# Altium schematic sheet CPU.SchDoc
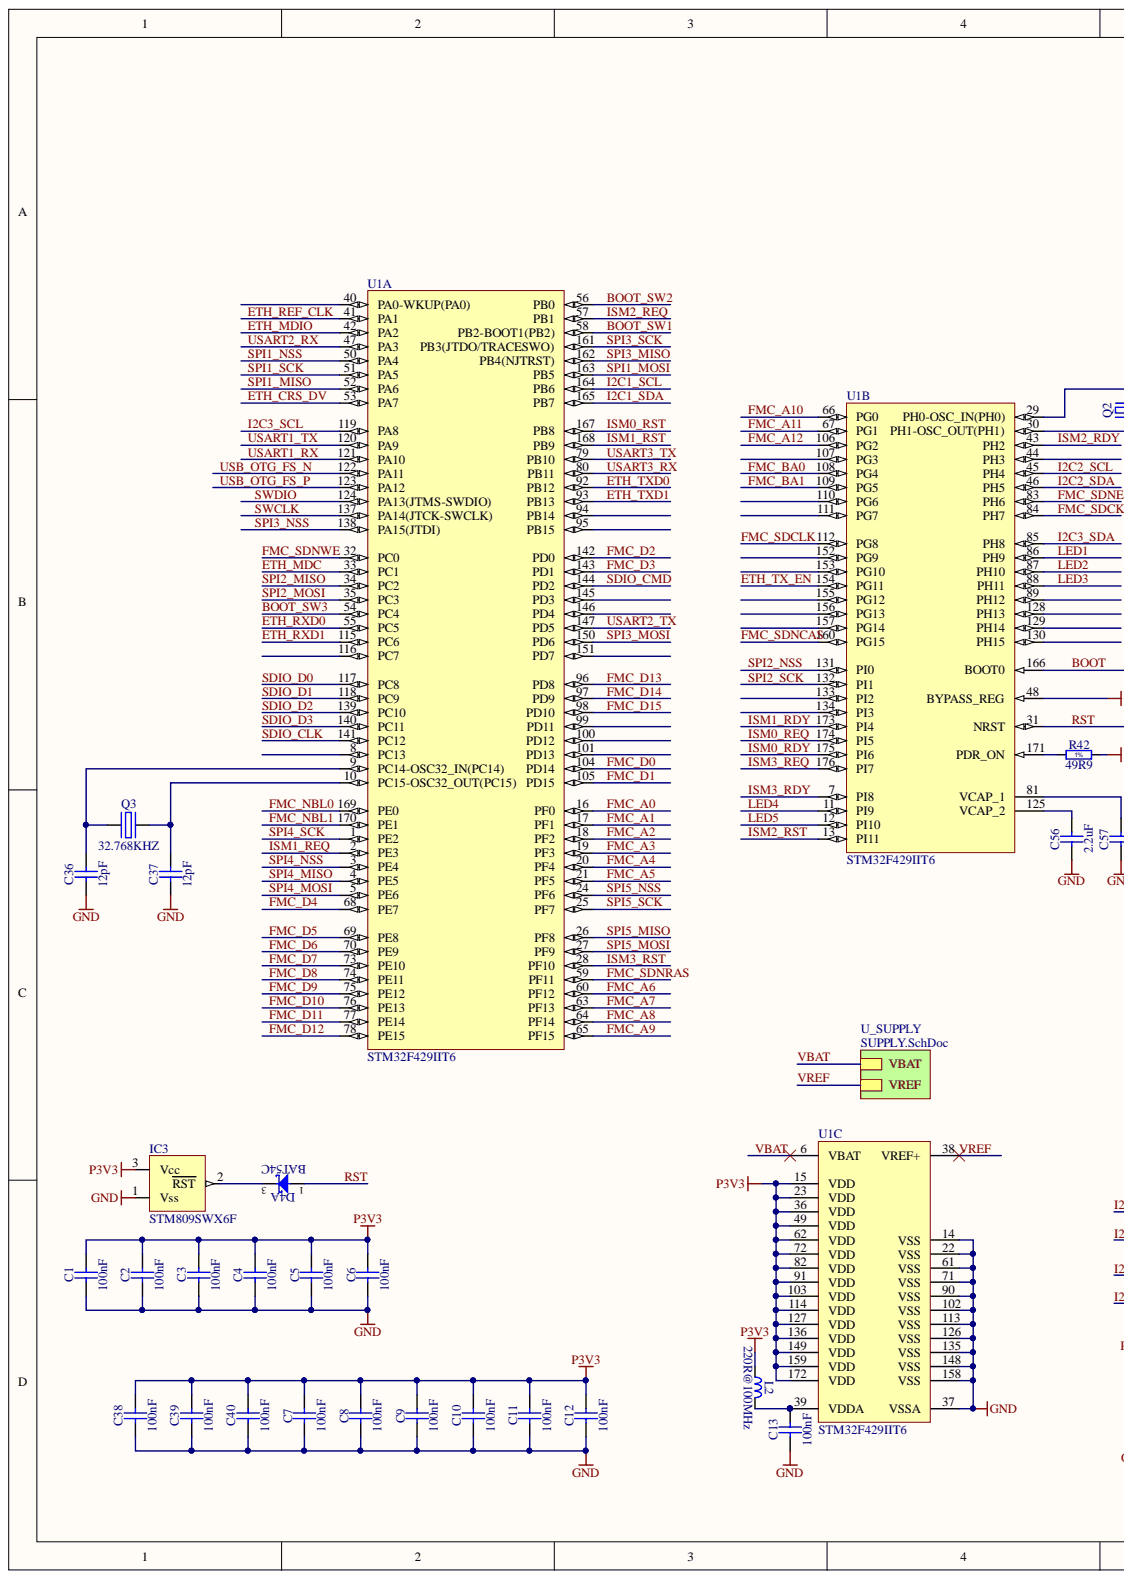
[diagram p0: CPU.SchDoc, left]
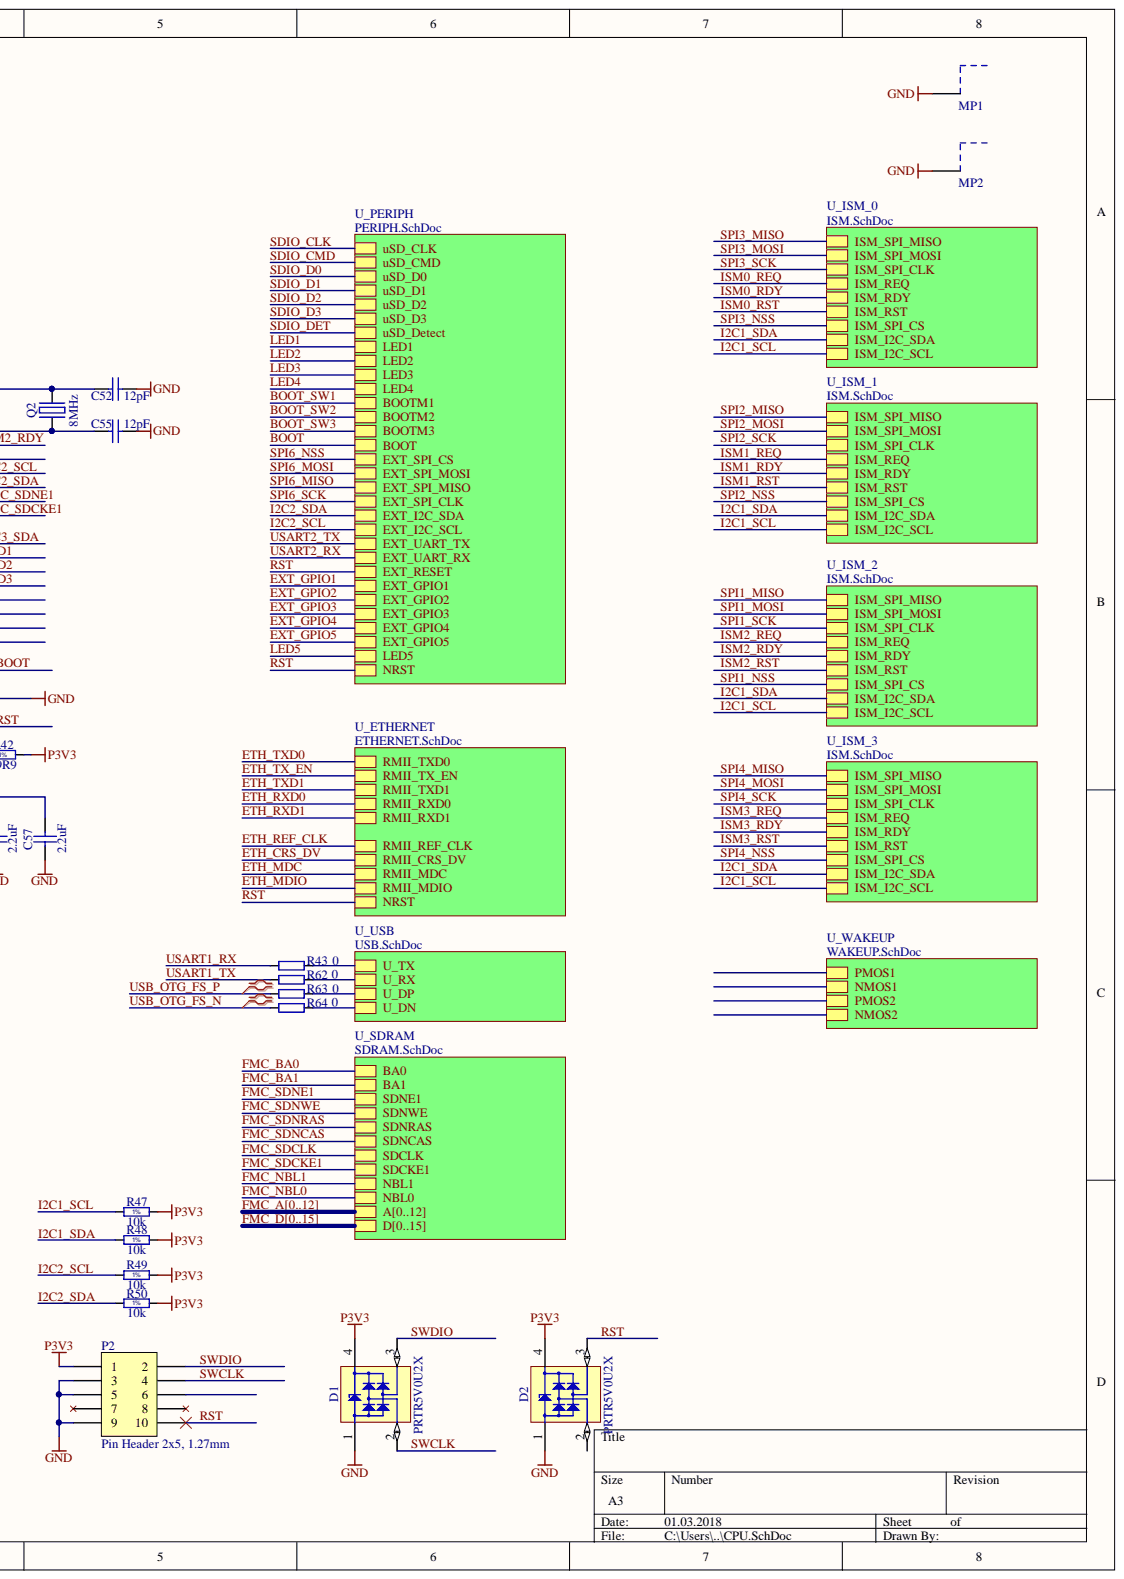
[diagram p1: CPU.SchDoc, right]

## Parts
C1  100nF  [CAPC1005X55N]
C2  100nF  [CAPC1005X55N]
C3  100nF  [CAPC1005X55N]
C4  100nF  [CAPC1005X55N]
C5  100nF  [CAPC1005X55N]
C6  100nF  [CAPC1005X55N]
C7  100nF  [CAPC1005X55N]
C8  100nF  [CAPC1005X55N]
C9  100nF  [CAPC1005X55N]
C10  100nF  [CAPC1005X55N]
C11  100nF  [CAPC1005X55N]
C12  100nF  [CAPC1005X55N]
C13  100nF  [CAPC1005X55N]
C36  12pF  [CAPC1005X55N]
C37  12pF  [CAPC1005X55N]
C38  100nF  [CAPC1005X55N]
C39  100nF  [CAPC1005X55N]
C40  100nF  [CAPC1005X55N]
C52  12pF  [CAPC1005X55N]
C55  12pF  [CAPC1005X55N]
C56  2.2uF  [CAPC1608X87N]
C57  2.2uF  [CAPC1608X87N]
D1  PRTR5V0U2X  [SOT190P230X110-4N]
D2  PRTR5V0U2X  [SOT190P230X110-4N]
D4  BAT54C  [SOT95P240X130-3N]  (part A)
IC3  STM809SWX6F  [SOT95P229X119-3N]
L2  220R@100MHz  [INDC2012X105N]
MP1  36103205S  [36103205S]  (value not drawn)
MP2  36103205S  [36103205S]  (value not drawn)
P2  Pin Header 2x5, 1.27mm  [HDR_2x5-SMD-1.27mm-K]
Q2  8MHz  [XTAL_TXC_7A]
Q3  32.768KHZ  [XTAL_ABRACON_ABS09]
R42  49R9  [RESC1005X40N]
R43  0  [RESC1005X40N]
R47  10k  [RESC1005X40N]
R48  10k  [RESC1005X40N]
R49  10k  [RESC1005X40N]
R50  10k  [RESC1005X40N]
R62  0  [RESC1005X40N]
R63  0  [RESC1005X40N]
R64  0  [RESC1005X40N]
U1  STM32F429IIT6  [STM-LQFP176_N]  (parts A,B,C)

## Nets
GND: C1.1 C2.1 C3.1 C4.1 C5.1 C6.1 C7.1 C8.1 C9.1 C10.1 C11.1 C12.1 C13.1 C36.1 C37.1 C38.1 C39.1 C40.1 C52.1 C55.1 C56.1 C57.1 D1.1 D2.1 IC3.1 MP1.1 MP2.1 P2.3 P2.5 P2.9 U1.14(VSS) U1.22(VSS) U1.37(VSSA) U1.48(BYPASS_REG) U1.61(VSS) U1.71(VSS) U1.90(VSS) U1.102(VSS) U1.113(VSS) U1.126(VSS) U1.135(VSS) U1.148(VSS) U1.158(VSS)
P3V3: C1.2 C2.2 C3.2 C4.2 C5.2 C6.2 C7.2 C8.2 C9.2 C10.2 C11.2 C12.2 C38.2 C39.2 C40.2 D1.4 D2.4 IC3.3 L2.1 P2.1 R42.2 R47.2 R48.2 R49.2 R50.2 U1.15(VDD) U1.23(VDD) U1.36(VDD) U1.49(VDD) U1.62(VDD) U1.72(VDD) U1.82(VDD) U1.91(VDD) U1.103(VDD) U1.114(VDD) U1.127(VDD) U1.136(VDD) U1.149(VDD) U1.159(VDD) U1.172(VDD)
BOOT: U1.166(BOOT0)
BOOT_SW1: U1.58(PB2-BOOT1(PB2))
BOOT_SW2: U1.56(PB0)
BOOT_SW3: U1.54(PC4)
ETH_CRS_DV: U1.53(PA7)
ETH_MDC: U1.33(PC1)
ETH_MDIO: U1.42(PA2)
ETH_REF_CLK: U1.41(PA1)
ETH_RXD0: U1.55(PC5)
ETH_RXD1: U1.115(PC6)
ETH_TX_EN: U1.154(PG11)
ETH_TXD0: U1.92(PB12)
ETH_TXD1: U1.93(PB13)
FMC_A0: U1.16(PF0)  [also labelled FMC_A]
FMC_A1: U1.17(PF1)  [also labelled FMC_A]
FMC_A2: U1.18(PF2)  [also labelled FMC_A]
FMC_A3: U1.19(PF3)  [also labelled FMC_A]
FMC_A4: U1.20(PF4)  [also labelled FMC_A]
FMC_A5: U1.21(PF5)  [also labelled FMC_A]
FMC_A6: U1.60(PF12)  [also labelled FMC_A]
FMC_A7: U1.63(PF13)  [also labelled FMC_A]
FMC_A8: U1.64(PF14)  [also labelled FMC_A]
FMC_A9: U1.65(PF15)  [also labelled FMC_A]
FMC_A10: U1.66(PG0)  [also labelled FMC_A]
FMC_A11: U1.67(PG1)  [also labelled FMC_A]
FMC_A12: U1.106(PG2)  [also labelled FMC_A]
FMC_BA0: U1.108(PG4)
FMC_BA1: U1.109(PG5)
FMC_D0: U1.104(PD14)  [also labelled FMC_D]
FMC_D1: U1.105(PD15)  [also labelled FMC_D]
FMC_D2: U1.142(PD0)  [also labelled FMC_D]
FMC_D3: U1.143(PD1)  [also labelled FMC_D]
FMC_D4: U1.68(PE7)  [also labelled FMC_D]
FMC_D5: U1.69(PE8)  [also labelled FMC_D]
FMC_D6: U1.70(PE9)  [also labelled FMC_D]
FMC_D7: U1.73(PE10)  [also labelled FMC_D]
FMC_D8: U1.74(PE11)  [also labelled FMC_D]
FMC_D9: U1.75(PE12)  [also labelled FMC_D]
FMC_D10: U1.76(PE13)  [also labelled FMC_D]
FMC_D11: U1.77(PE14)  [also labelled FMC_D]
FMC_D12: U1.78(PE15)  [also labelled FMC_D]
FMC_D13: U1.96(PD8)  [also labelled FMC_D]
FMC_D14: U1.97(PD9)  [also labelled FMC_D]
FMC_D15: U1.98(PD10)  [also labelled FMC_D]
FMC_NBL0: U1.169(PE0)
FMC_NBL1: U1.170(PE1)
FMC_SDCKE1: U1.84(PH7)
FMC_SDCLK: U1.112(PG8)
FMC_SDNCAS: U1.160(PG15)
FMC_SDNE1: U1.83(PH6)
FMC_SDNRAS: U1.59(PF11)
FMC_SDNWE: U1.32(PC0)
I2C1_SCL: R47.1 U1.164(PB6)
I2C1_SDA: R48.1 U1.165(PB7)
I2C2_SCL: R49.1 U1.45(PH4)
I2C2_SDA: R50.1 U1.46(PH5)
I2C3_SCL: U1.119(PA8)
I2C3_SDA: U1.85(PH8)
ISM0_RDY: U1.175(PI6)
ISM0_REQ: U1.174(PI5)
ISM0_RST: U1.167(PB8)
ISM1_RDY: U1.173(PI4)
ISM1_REQ: U1.2(PE3)
ISM1_RST: U1.168(PB9)
ISM2_RDY: U1.43(PH2)
ISM2_REQ: U1.57(PB1)
ISM2_RST: U1.13(PI11)
ISM3_RDY: U1.7(PI8)
ISM3_REQ: U1.176(PI7)
ISM3_RST: U1.28(PF10)
LED1: U1.86(PH9)
LED2: U1.87(PH10)
LED3: U1.88(PH11)
LED4: U1.11(PI9)
LED5: U1.12(PI10)
RST: D2.3 D4.1 P2.10 U1.31(NRST)
SDIO_CLK: U1.141(PC12)
SDIO_CMD: U1.144(PD2)
SDIO_D0: U1.117(PC8)
SDIO_D1: U1.118(PC9)
SDIO_D2: U1.139(PC10)
SDIO_D3: U1.140(PC11)
SPI1_MISO: U1.52(PA6)
SPI1_MOSI: U1.163(PB5)
SPI1_NSS: U1.50(PA4)
SPI1_SCK: U1.51(PA5)
SPI2_MISO: U1.34(PC2)
SPI2_MOSI: U1.35(PC3)
SPI2_NSS: U1.131(PI0)
SPI2_SCK: U1.132(PI1)
SPI3_MISO: U1.162(PB4(NJTRST))
SPI3_MOSI: U1.150(PD6)
SPI3_NSS: U1.138(PA15(JTDI))
SPI3_SCK: U1.161(PB3(JTDO/TRACESWO))
SPI4_MISO: U1.4(PE5)
SPI4_MOSI: U1.5(PE6)
SPI4_NSS: U1.3(PE4)
SPI4_SCK: U1.1(PE2)
SPI5_MISO: U1.26(PF8)
SPI5_MOSI: U1.27(PF9)
SPI5_NSS: U1.24(PF6)
SPI5_SCK: U1.25(PF7)
SWCLK: D1.2 P2.4 U1.137(PA14(JTCK-SWCLK))
SWDIO: D1.3 P2.2 U1.124(PA13(JTMS-SWDIO))
USART1_RX: R43.1 U1.121(PA10)
USART1_TX: R62.2 U1.120(PA9)
USART2_RX: U1.47(PA3)
USART2_TX: U1.147(PD5)
USART3_RX: U1.80(PB11)
USART3_TX: U1.79(PB10)
USB_OTG_FS_N: R64.2 U1.122(PA11)
USB_OTG_FS_P: R63.1 U1.123(PA12)
VBAT: U1.6(VBAT)
VREF: U1.38(VREF+)
NetC13_2: C13.2 L2.2 U1.39(VDDA)
NetC36_2: C36.2 Q3.1 U1.9(PC14-OSC32_IN(PC14))
NetC37_2: C37.2 Q3.2 U1.10(PC15-OSC32_OUT(PC15))
NetC52_2: C52.2 Q2.2 U1.29(PH0-OSC_IN(PH0))
NetC55_2: C55.2 Q2.1 U1.30(PH1-OSC_OUT(PH1))
NetC56_2: C56.2 U1.125(VCAP_2)
NetC57_2: C57.2 U1.81(VCAP_1)
NetD2_2: D2.2
NetD4_3: D4.3 IC3.2
NetP2_6: P2.6
NetP2_7: P2.7
NetP2_8: P2.8
NetR42_1: R42.1 U1.171(PDR_ON)
NetR43_2: R43.2
NetR62_1: R62.1
NetR63_2: R63.2
NetR64_1: R64.1
NetU1_8: U1.8(PC13)
NetU1_40: U1.40(PA0-WKUP(PA0))
NetU1_44: U1.44(PH3)
NetU1_89: U1.89(PH12)
NetU1_94: U1.94(PB14)
NetU1_95: U1.95(PB15)
NetU1_99: U1.99(PD11)
NetU1_100: U1.100(PD12)
NetU1_101: U1.101(PD13)
NetU1_107: U1.107(PG3)
NetU1_110: U1.110(PG6)
NetU1_111: U1.111(PG7)
NetU1_116: U1.116(PC7)
NetU1_128: U1.128(PH13)
NetU1_129: U1.129(PH14)
NetU1_130: U1.130(PH15)
NetU1_133: U1.133(PI2)
NetU1_134: U1.134(PI3)
NetU1_145: U1.145(PD3)
NetU1_146: U1.146(PD4)
NetU1_151: U1.151(PD7)
NetU1_152: U1.152(PG9)
NetU1_153: U1.153(PG10)
NetU1_155: U1.155(PG12)
NetU1_156: U1.156(PG13)
NetU1_157: U1.157(PG14)
EXT_GPIO1:
EXT_GPIO2:
EXT_GPIO3:
EXT_GPIO4:
EXT_GPIO5:
NMOS1:
NMOS2:
PMOS1:
PMOS2:
SDIO_DET:
SPI6_MISO:
SPI6_MOSI:
SPI6_NSS:
SPI6_SCK:

## Library data of the parts
C1-C13 C38-C40 = CC0402_100NF_16V_10%_X5R: Non-polarised capacitor; Manufacturer: GENERIC; Manufacturer1 Part Number: GRM155R61C104KA88D; Part Description: SMD Multilayer Chip Ceramic Capacitor; Database Table Name: Capacitors SMD; TC: X5R; Tolerance: ±10%; Voltage: 16V; Case: 0402; Component Kind: Standard; Component Type: Standard; CreateDate: 2013-12-10; HelpURL: \\cern.ch\dfs\Applications\Altium\Datasheets\CC0402_X5R_MURATA_GRM.pdf; LatestRevisionDate: 2013-12-10; Library Name: Capacitors.DbLib; Manufacturer1 ComponentHeight: 0.55mm; Manufacturer1 Example: MURATA; Manufacturer Part Number: CC0402_100NF_16V_10%_X5R; Mounted: Yes; Part Number: CC0402_100NF_16V_10%_X5R; Pin Count: 2; Sense: No; SMD: Yes; Socket: No; Status: None; Value: 100nF; Author: CERN DEM JLC
C36 C37 C52 C55 = CC0402_12PF_50V_5%_C0G: Non-polarised capacitor; Manufacturer: GENERIC; Manufacturer1 Part Number: C0402C120J5GACTU; Part Description: SMD Multilayer Chip Ceramic Capacitor; Database Table Name: Capacitors SMD; TC: C0G/NP0; Tolerance: ±5%; Voltage: 50V; Case: 0402; Component Kind: Standard; Component Type: Standard; CreateDate: 2016-10-04; HelpURL: \\cern.ch\dfs\Applications\Altium\Datasheets\CC0805_C0G_KEMET_(Commercial Grade).pdf; LatestRevisionDate: 2016-10-04; Library Name: Capacitors.DbLib; Manufacturer1 ComponentHeight: 0.55mm; Manufacturer1 Example: KEMET; Manufacturer Part Number: CC0402_12PF_50V_5%_C0G; Mounted: Yes; Part Number: CC0402_12PF_50V_5%_C0G; Pin Count: 2; Sense: No; SMD: Yes; Socket: No; Status: Preferred; Value: 12pF; Author: CERN DEM JLC
C56 C57 = CC0603_2.2UF_16V_10%_X5R: Non-polarised capacitor; Manufacturer: GENERIC; Manufacturer1 Part Number: CC0603KRX5R7BB225; Part Description: SMD Multilayer Chip Ceramic Capacitor; Database Table Name: Capacitors SMD; TC: X5R; Tolerance: ±10%; Voltage: 16V; Case: 0603; Component Kind: Standard; Component Type: Standard; CreateDate: 2011-04-28; HelpURL: \\cern.ch\dfs\Applications\Altium\Datasheets\CC0805_X5R_PHYCOMP_HC.pdf; LatestRevisionDate: 2011-04-28; Library Name: Capacitors.DbLib; Manufacturer1 ComponentHeight: 0.9mm; Manufacturer1 Example: YAGEO; Manufacturer Part Number: CC0603_2.2UF_16V_10%_X5R; Mounted: Yes; Part Number: CC0603_2.2UF_16V_10%_X5R; Pin Count: 2; Sense: No; SMD: Yes; Socket: No; Status: Preferred; Value: 2.2uF; Author: CERN DEM JLC
D1 D2 = PRTR5V0U2X: Ultra Low Capacitance Double Rail-to-Rail ESD Protection Diode; Manufacturer: NXP SEMICONDUCTORS; Part Description: Ultra Low Capacitance (1pF) Double Rail-to-Rail ESD Protection Diode (8kV); Bonding: No; Database Table Name: Diodes; PressFit: No; Voltage: 5.5V; Case: SOT143B; ComponentHeight: 1.1mm; Component Kind: Standard; Component Type: Standard; CreateDate: 22.03.2011; Device: PRTR5V0U2X; HelpURL: \\cern.ch\dfs\Applications\Altium\Datasheets\PRTR5V0U2X.pdf; LatestRevisionDate: 22.03.2011; Library Name: ICs And Semiconductors.DbLib; Manufacturer Part Number: PRTR5V0U2X; Mounted: Yes; PackageDescription: SOT143B, SOT, 1.90mm Pitch; 4 Pin, 1.30mm W X 2.90mm L X 1.10mm H body, IPC Medium Density; Part Number: PRTR5V0U2X; Pin Count: 4; Sense: No; SMD: Yes; Socket: No; Author: CERN DEM JLC
D4 = BAT54C: Dual Common Cathode Schottky Diodes; Manufacturer: FAIRCHILD SEMICONDUCTOR; Part Description: Dual Common Cathode Small Signal Schottky Diode; Bonding: No; Power: 200mA; PressFit: No; Voltage: 30V; Case: SOT23-3; ComponentHeight: 1.30mm; Component Kind: Standard; Component Type: Standard; CreateDate: 2009-09-17; Database Table Name: Diodes; Device: BAT54C; HelpURL: \\cern.ch\dfs\Applications\Altium\Datasheets\BAT54C.pdf; LatestRevisionDate: 2010-05-27; Library Name: ICs And Semiconductors.DbLib; Manufacturer Part Number: BAT54C; Mounted: Yes; PackageDescription: SOT23 3-Leads, Pitch 0.95mm - Body 1.3x2.9mm, IPC Medium Density; Part Number: BAT54C; Pin Count: 3; Sense: No; SMD: Yes; Socket: No; Author: CERN DEM JLC
IC3 = VMON_STM809: 2.93V  Reset circuit; Component Kind: Standard; Library Name: LIBRARY_SCH.SCHLIB
L2 = IND0805_MURATA_BLM21PG221SN1D: Inductor; Manufacturer: MURATA; Part Description: SMD EMIFIL Suppression Chip Ferrite Bead; Database Table Name: Inductors SMD; Power: 2A; Resistance: 0.05R; Tolerance: ±25%; Case: 0805; ComponentHeight: 1.05mm; Component Kind: Standard; Component Type: Standard; CreateDate: 2010-01-25; HelpURL: \\cern.ch\dfs\Applications\Altium\Datasheets\IND0402_MURATA_BLM21P.pdf; LatestRevisionDate: 2010-01-25; Library Name: Inductors & Transformers.DbLib; Manufacturer Part Number: BLM21PG221SN1D; Mounted: Yes; Part Number: IND0805_MURATA_BLM21PG221SN1D; Pin Count: 2; Sense: No; SMD: Yes; Socket: No; Status: None; Value: 220R@100MHz; Author: CERN DEM JLC
MP1 MP2 = 36103205S: WE-SHC Shielding Cabinet, SMD Frame, 20 x 20 x 3 mm; Component Kind: Standard; ComponentLink1Description: Manufacturer; ComponentLink1URL: http://www.we-online.de; ComponentLink2Description: Datasheet; ComponentLink2URL: http://katalog.we-online.de/pbs/datasheet/36103205S.pdf; Library Name: ALTIUM-WE-SHC-rev17b.IntLib; Part Number: 36103205S; Published Date: April 2017; Size: 20 x 20 x 3 mm; Type: SMD Frame; Publisher: Wurth Elektronik
P2 = Pin Header 2x5 SMD, 1.27mm, KS: Pin Header 2x5, 1.27mm (50mil), SMD, Keying Shroud; 2nd source part number: FTSH-105-01-F-DV-K; Manufacturer: WCON; Manufacturer Part Number: 3132-10MS0BK00R1; Artikkelnr Noca: 55010009; Artikkelnr Simpro: N.A.; Database Table Name: Connectors$; 2nd source Supplier: Samtec; Component Kind: Standard; Datasheet: \\hizz\pro\app9717\Datasheet\connectores\W-CON\3132-XXMXXBK00XX.pdf; Footprint Doc: HDR_2x5-SMD-1.27mm; LatestRevisionDate: 2016-04-21; Library Name: Nordic Passive DB.DbLib; Part Number: Pin Header 2x5 SMD, 1.27mm, KS; Pin Count: 10; Published: 2016-01-22; Supplier: Cabcon; Supplier part number: 3132-10MS0BK00R1; Value: Pin Header 2x5, 1.27mm; Publisher: Nordic Semiconductor
Q2 = XTAL_8MHZ_TXC_7A-8.000MAAE-T: Quartz Crystal; Manufacturer: TXC CORPORATION; Part Description: Tolerance: ±30ppm, Stability: ±30ppm, -20°C to +70°C, 12pF, SMD Glass Seam Sealing Crystal, 7A Series; Bonding: No; Database Table Name: Crystals & Oscillators; PressFit: No; ComponentHeight: 1.2mm; Component Kind: Standard; Component Type: Standard; CreateDate: 09.10.2014; HelpURL: \\cern.ch\dfs\Applications\Altium\Datasheets\XTAL_TXC_7A.pdf; LatestRevisionDate: 12.11.2014; Library Name: ICs And Semiconductors.DbLib; Manufacturer Part Number: 7A-8.000MAAE-T; Mounted: Yes; PackageDescription: 2 Pins, 3.2x5mm, SMD Glass Seam Sealing Crystal, 7A Serie; Part Number: XTAL_8MHZ_TXC_7A-8.000MAAE-T; Pin Count: 2; Sense: No; SMD: Yes; Socket: No; Value: 8MHz; Author: CERN DEM JLC
Q3 = XTAL_32.768KHZ_ABRACON_ABS09-32.768KHZ-T: Quartz Crystal; Part Description: Tolerance: ±20ppm, Stability: ±5ppm, -40°C to +85°C, 12.5pF, SMD Low Profile Crystal, ABS09 Series; Bonding: No; Database Table Name: Crystals & Oscillators; PressFit: No; ComponentHeight: 0.9mm; Component Kind: Standard; Component Type: Standard; CreateDate: 2014-10-16; HelpURL: \\cern.ch\dfs\Applications\Altium\Datasheets\XTAL_ABRACON_ABS09.pdf; LatestRevisionDate: 2014-10-16; Library Name: ICs And Semiconductors.DbLib; Manufacturer: ABRACON; Manufacturer Part Number: ABS09-32.768KHZ-T; Mounted: Yes; PackageDescription: 2 Pins, 4.1x1.5mm, SMD Low Profile Crystal, ABS09 Serie; Part Number: XTAL_32.768KHZ_ABRACON_ABS09-32.768KHZ-T; Pin Count: 2; Sense: No; SMD: Yes; Socket: No; Value: 32.768KHZ; Author: CERN DEM JLC
R42 = R0402_49R9_1%_0.0625W_100PPM: Resistor - 1%; Manufacturer: GENERIC; Manufacturer1 Part Number: 232270674999L or RC0402FR-0749R9L; Part Description: General Purpose Thick Film Chip Resistor; Database Table Name: Resistors SMD; TC: ±100ppm/°C; Case: 0402; Component Kind: Standard; Component Type: Standard; CreateDate: 2012-10-24; HelpURL: \\cern.ch\dfs\Applications\Altium\Datasheets\R0402_Phycomp_RC0402.pdf; LatestRevisionDate: 2012-10-24; Library Name: Resistors.DbLib; Manufacturer1 ComponentHeight: 0.4mm; Manufacturer1 Example: YAGEO PHYCOMP; Manufacturer Part Number: R0402_49R9_1%_0.0625W_100PPM; Mounted: Yes; Part Number: R0402_49R9_1%_0.0625W_100PPM; Pin Count: 2; Power: 0.0625W; Sense: No; SMD: Yes; Socket: No; Status: Not recommended; Tolerance: ±1%; Value: 49R9; Author: CERN DEM JLC
R43 R62-R64 = R0402_0R_JUMPER: Resistor; Manufacturer: GENERIC; Manufacturer1 Part Number: 232270591001L; Part Description: 1A (0.05R Max DC Resistance) Zero Ohm Jumper; Database Table Name: Resistors SMD; Case: 0402; Component Kind: Standard; Component Type: Standard; CreateDate: 2007-12-03; HelpURL: \\cern.ch\dfs\Applications\Altium\Datasheets\R0402_Phycomp_RC0402.pdf; LatestRevisionDate: 2012-10-17; Library Name: Resistors.DbLib; Manufacturer1 ComponentHeight: 0.4mm; Manufacturer1 Example: YAGEO PHYCOMP; Manufacturer Part Number: R0402_0R_JUMPER; Mounted: Yes; Part Number: R0402_0R_JUMPER; Pin Count: 2; Sense: No; SMD: Yes; Socket: No; Status: Not recommended; Value: 0; Author: CERN DEM JLC
R47-R50 = R0402_10K_1%_0.0625W_100PPM: Resistor - 1%; Manufacturer: GENERIC; Manufacturer1 Part Number: 232270671003L; Part Description: General Purpose Thick Film Chip Resistor; Database Table Name: Resistors SMD; TC: ±100ppm/°C; Case: 0402; Component Kind: Standard; Component Type: Standard; CreateDate: 2007-12-03; HelpURL: \\cern.ch\dfs\Applications\Altium\Datasheets\R0402_Phycomp_RC0402.pdf; LatestRevisionDate: 2012-10-17; Library Name: Resistors.DbLib; Manufacturer1 ComponentHeight: 0.4mm; Manufacturer1 Example: YAGEO PHYCOMP; Manufacturer Part Number: R0402_10K_1%_0.0625W_100PPM; Mounted: Yes; Part Number: R0402_10K_1%_0.0625W_100PPM; Pin Count: 2; Power: 0.0625W; Sense: No; SMD: Yes; Socket: No; Status: Not recommended; Tolerance: ±1%; Value: 10k; Author: CERN DEM JLC
U1 = STM32F429IIT6: ARM Cortex-M4 32-bit MCU+FPU, 225 DMIPS, 2048 kB Flash, 256 kB Internal RAM, 140 I/Os, 176-pin LQFP, -40 to 85 degC, Tray; Manufacturer: STMicroelectronics; D/A Converter: 2x12-bit; FLASH Size (Prog) (kB): 2048; I/Os (High Current): 140; Internal RAM Size (kB): 256; Operating Frequency(F) (Processor speed) (MHz): 180; Supply Voltage(Vcc) max (V): 3.6; Supply Voltage(Vcc) min (V): 1.8; Component Kind: Standard; ComponentLink1Description: Manufacturer URL; ComponentLink1URL: http://www.st.com/web/en/home.html; ComponentLink2Description: Datasheet; ComponentLink2URL: http://www.st.com/st-web-ui/static/active/en/resource/technical/document/data_brief/DM00053488.pdf; ComponentLink3Description: Package Specification; ComponentLink3URL: http://www.st.com/st-web-ui/static/active/en/resource/technical/document/datasheet/DM00035129.pdf; Core: ARM Cortex-M4; DatasheetVersion: Rev. 2; Library Name: STMicroelectronics STM32 F4.IntLib; Mounting Technology: Surface Mount; PackageDescription: 176-Pin Low Profile Quad Flat Package, 24 x 24 mm Body, 0.5 mm Pitch; PackageReference: LQFP176; PackageVersion: Rev. 4, 06/2013; Packing: Tray; PartNumber: STM32F429IIT6; Supplier 1: Digi-Key; Supplier 2: Newark; Supplier 3: Mouser; Supplier Part Number 1: 497-14054-ND; Supplier Part Number 2: 06X3672; Supplier Part Number 3: 511-STM32F429IIT6
